# Altium schematic sheet PAGE4_RELAYS.SchDoc
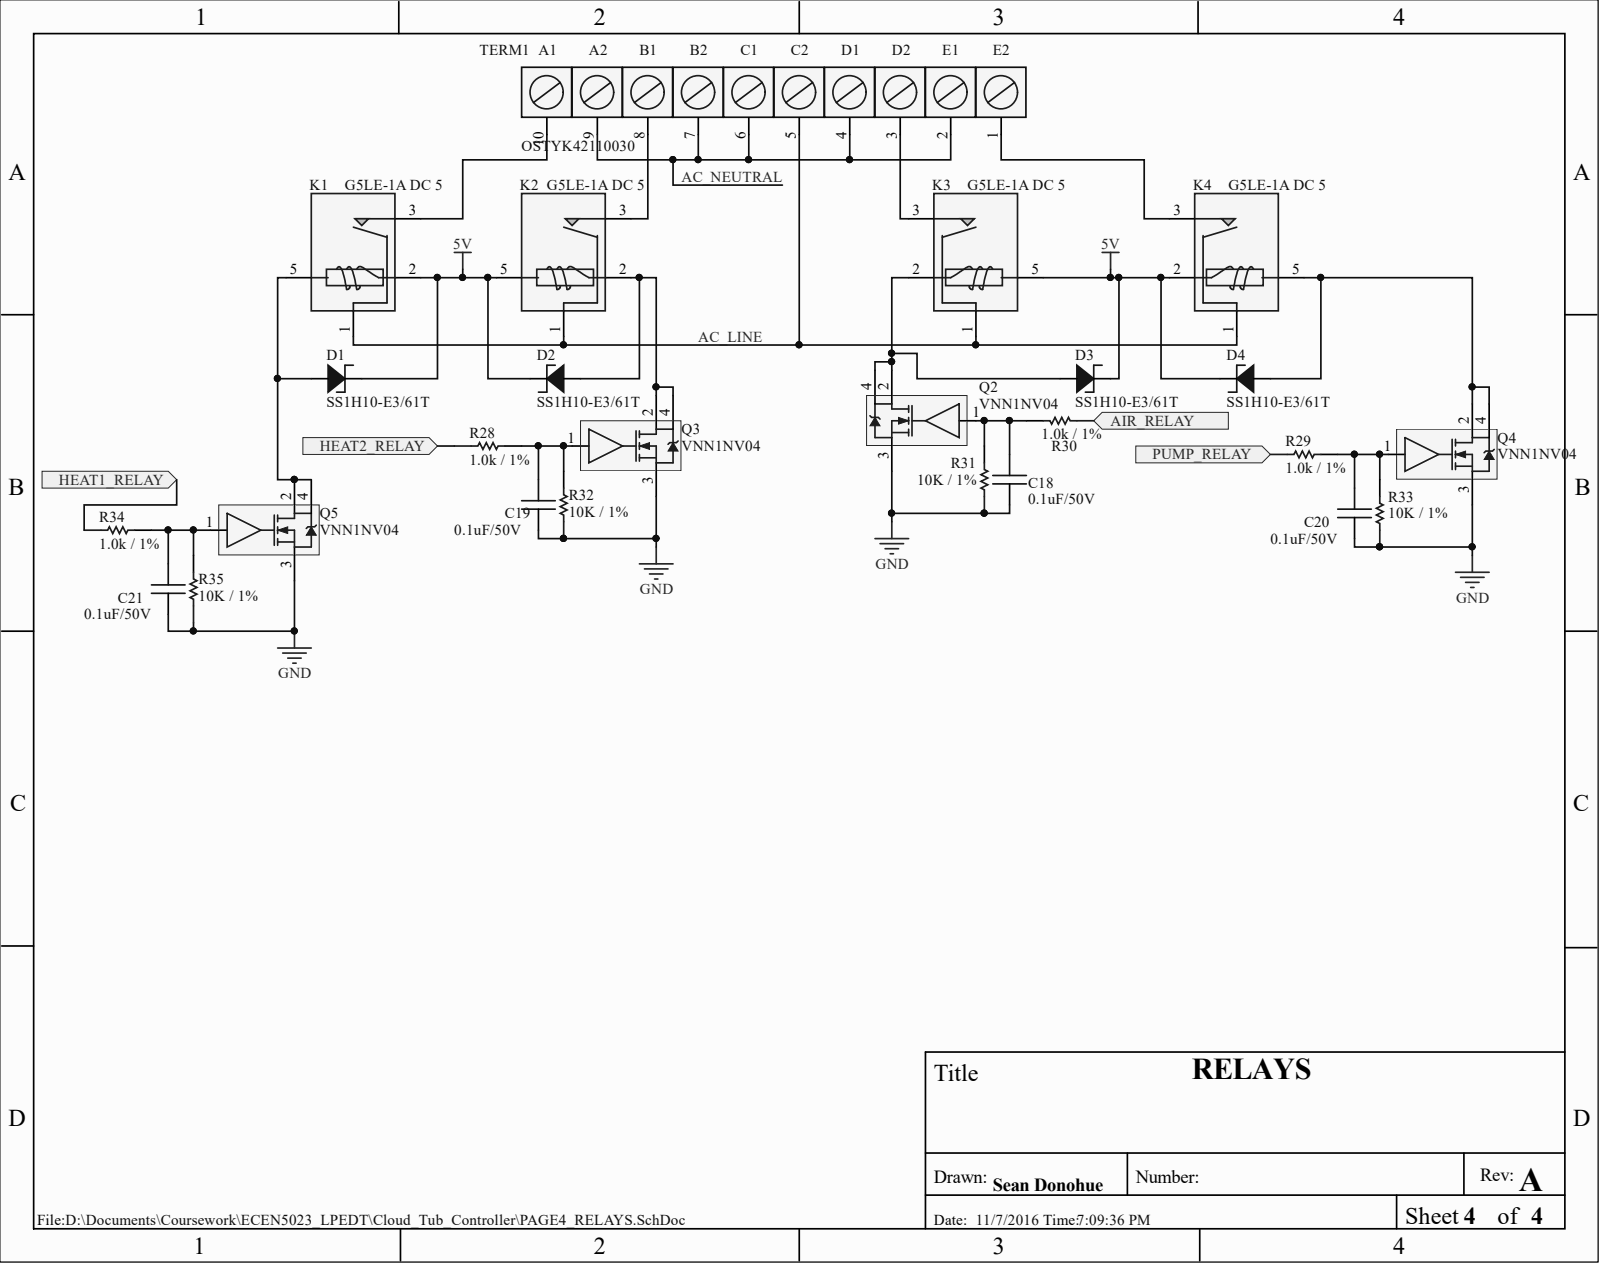
[diagram p0: PAGE4_RELAYS.SchDoc]

## Parts
C18  value 0.1uF/50V  [0603]  (hidden comment: cap)
C19  value 0.1uF/50V  [0603]  (hidden comment: cap)
C20  value 0.1uF/50V  [0603]  (hidden comment: cap)
C21  value 0.1uF/50V  [0603]  (hidden comment: cap)
D1  value SS1H10-E3/61T  MfgPN: SS1H10-E3/61T  [SMAZ]  (hidden comment: ds)
D2  value SS1H10-E3/61T  MfgPN: SS1H10-E3/61T  [SMAZ]  (hidden comment: ds)
D3  value SS1H10-E3/61T  MfgPN: SS1H10-E3/61T  [SMAZ]  (hidden comment: ds)
D4  value SS1H10-E3/61T  MfgPN: SS1H10-E3/61T  [SMAZ]  (hidden comment: ds)
K1  value G5LE-1A DC 5  [G5LE-SPST]  (hidden comment: G5LE-SPST)
K2  value G5LE-1A DC 5  [G5LE-SPST]  (hidden comment: G5LE-SPST)
K3  value G5LE-1A DC 5  [G5LE-SPST]  (hidden comment: G5LE-SPST)
K4  value G5LE-1A DC 5  [G5LE-SPST]  (hidden comment: G5LE-SPST)
Q2  value VNN1NV04  [SOT-223-4]  (hidden comment: NMOS-SOT-223-4)
Q3  value VNN1NV04  [SOT-223-4]  (hidden comment: NMOS-SOT-223-4)
Q4  value VNN1NV04  [SOT-223-4]  (hidden comment: NMOS-SOT-223-4)
Q5  value VNN1NV04  [SOT-223-4]  (hidden comment: NMOS-SOT-223-4)
R28  value 1.0k / 1%  [0603]  (hidden comment: Res)
R29  value 1.0k / 1%  [0603]  (hidden comment: Res)
R30  value 1.0k / 1%  [0603]  (hidden comment: Res)
R31  value 10K / 1%  [0603]  (hidden comment: Res)
R32  value 10K / 1%  [0603]  (hidden comment: Res)
R33  value 10K / 1%  [0603]  (hidden comment: Res)
R34  value 1.0k / 1%  [0603]  (hidden comment: Res)
R35  value 10K / 1%  [0603]  (hidden comment: Res)
TERM1  value OSTYK42110030  MfgPN: OSTYK42110030  [BARRIER-STRP-10]  (hidden comment: BARR-STRP-10)

## Ports
AIR_RELAY, HEAT1_RELAY, HEAT2_RELAY, PUMP_RELAY

## Nets
5V: D1.1 D2.1 D3.1 D4.1 K1.2 K2.5 K3.5 K4.2
GND: C18.2 C19.2 C20.2 C21.2 Q2.3 Q3.3 Q4.3 Q5.3 R31.1 R32.1 R33.1 R35.1
AC_LINE: K1.1 K2.1 K3.1 K4.1 TERM1.5
AC_NEUTRAL: TERM1.2 TERM1.4 TERM1.6 TERM1.7 TERM1.9
AIR_RELAY: R30.1 ; port AIR_RELAY
HEAT1_RELAY: R34.1 ; port HEAT1_RELAY
HEAT2_RELAY: R28.1 ; port HEAT2_RELAY
PUMP_RELAY: R29.1 ; port PUMP_RELAY
NetC18_1: C18.1 Q2.1 R30.2 R31.2
NetC19_1: C19.1 Q3.1 R28.2 R32.2
NetC20_1: C20.1 Q4.1 R29.2 R33.2
NetC21_1: C21.1 Q5.1 R34.2 R35.2
NetD1_2: D1.2 K1.5 Q5.2 Q5.4
NetD2_2: D2.2 K2.2 Q3.2 Q3.4
NetD3_2: D3.2 K3.2 Q2.2 Q2.4
NetD4_2: D4.2 K4.5 Q4.2 Q4.4
NetK1_3: K1.3 TERM1.10
NetK2_3: K2.3 TERM1.8
NetK3_3: K3.3 TERM1.3
NetK4_3: K4.3 TERM1.1

## Library data of the parts
C18-C21 = ECM1045XQ: CAP CER 0.1UF 50V 10% X7R 0603; Alt Mfg: TDK; Alt PN: C1608X7R1H104K; COST/10: 0.041; COST/50: 0.0222; COST/100: 0.0187; COST/500: 0.0131; Database Table Name: CAP$; Mfg: Murata; MfgPN: GRM188R71H104KA93D; Temp: -55°C ~ 125°C; Unit Cost: 0.1; Vendor: Digikey; VendorPN: 490-1519-1-ND; Component Kind: Standard; EetrexPN: ECM1045XQ; Library Name: eetrex comp db.DbLib
D1-D4 = ED93: DIODE SCHOTTKY 100V 1A DO214AC; Database Table Name: DIODE$; Mfg: Vishay; TEMP: 175°C; Unit Cost: 0.371; Vendor: Digikey; VendorPN: SS1H10-E3/61TGICT-ND; Component Kind: Standard; EetrexPN: ED93; Library Name: eetrex comp db.DbLib
K1-K4 = ELME53: RELAY GEN PURPOSE SPST 16A 5V; Database Table Name: ELECTROMECH$; Mfg: Omron; MfgPN: G5LE-1A-E DC5; TEMP: -40°C ~ 85°C; Unit Cost: 2.3; Vendor: Digikey; VendorPN: Z2619-ND; Component Kind: Standard; EetrexPN: ELME53; Library Name: eetrex comp db.DbLib
Q2-Q5 = EXS0413NT: MOSFET N-CH 40V 1.7A SOT223; COST/10: 1.05; COST/50: 0.9476; COST/100: 0.8291; COST/500: 0.64484; Database Table Name: IC$; Mfg: ST Micro; MfgPN: VNN1NV0413TR; Ref: E133; Temp: '-40°C ~ 150°C; Unit Cost: 1.19; Vendor: Digikey; VendorPN: 497-11713-1-ND; Component Kind: Standard; EetrexPN: EXS0413NT; Library Name: eetrex comp db.DbLib
R28-R30 R34 = ERE1001FP: RES 1.00K OHM 1/10W 1% 0603 SMD; COST/10: 0.081; COST/50: 0.0436; Database Table Name: RES$; Mfg: Vishay; MfgPN: CRCW06031K00FKEA; Temp: -55°C ~ 155°C; Vendor: Digikey; VendorPN: 541-1.00KHCT-ND; Component Kind: Standard; COST/100: 0.0436; COST/500: 0.0436; EetrexPN: ERE1001FP; Signal Integrity: Res; Simulation: RESISTOR; Unit Cost: 0.081; Library Name: eetrex comp db.DbLib
R31-R33 R35 = ER275: RES 10K OHM 1% 1/8W 0603 SMD; Database Table Name: RES$; Mfg: Vishay; MfgPN: MCT06030C1002FP500; Temp: -55°C ~ 155°C; Unit Cost: 0.17; Value: 10K / 1%; Vendor: Digikey; VendorPN: MCT0603-10.0K-CFCT-ND; Component Kind: Standard; EetrexPN: ER275; Signal Integrity: Res; Simulation: RESISTOR; Library Name: eetrex comp db.DbLib
TERM1 = EKP113: CONN BARRIER STRP 10CIRC 0.325"; Database Table Name: CONN$; Mfg: On Shore Technology, Inc.; Unit Cost: 2.25; Vendor: Digikey; VendorPN: ED2952-ND; Component Kind: Standard; EetrexPN: EKP113; Library Name: eetrex comp db.DbLib
